# Altium schematic sheet Drive_Step_Motor.SchDoc(Drive_Step_Motor)
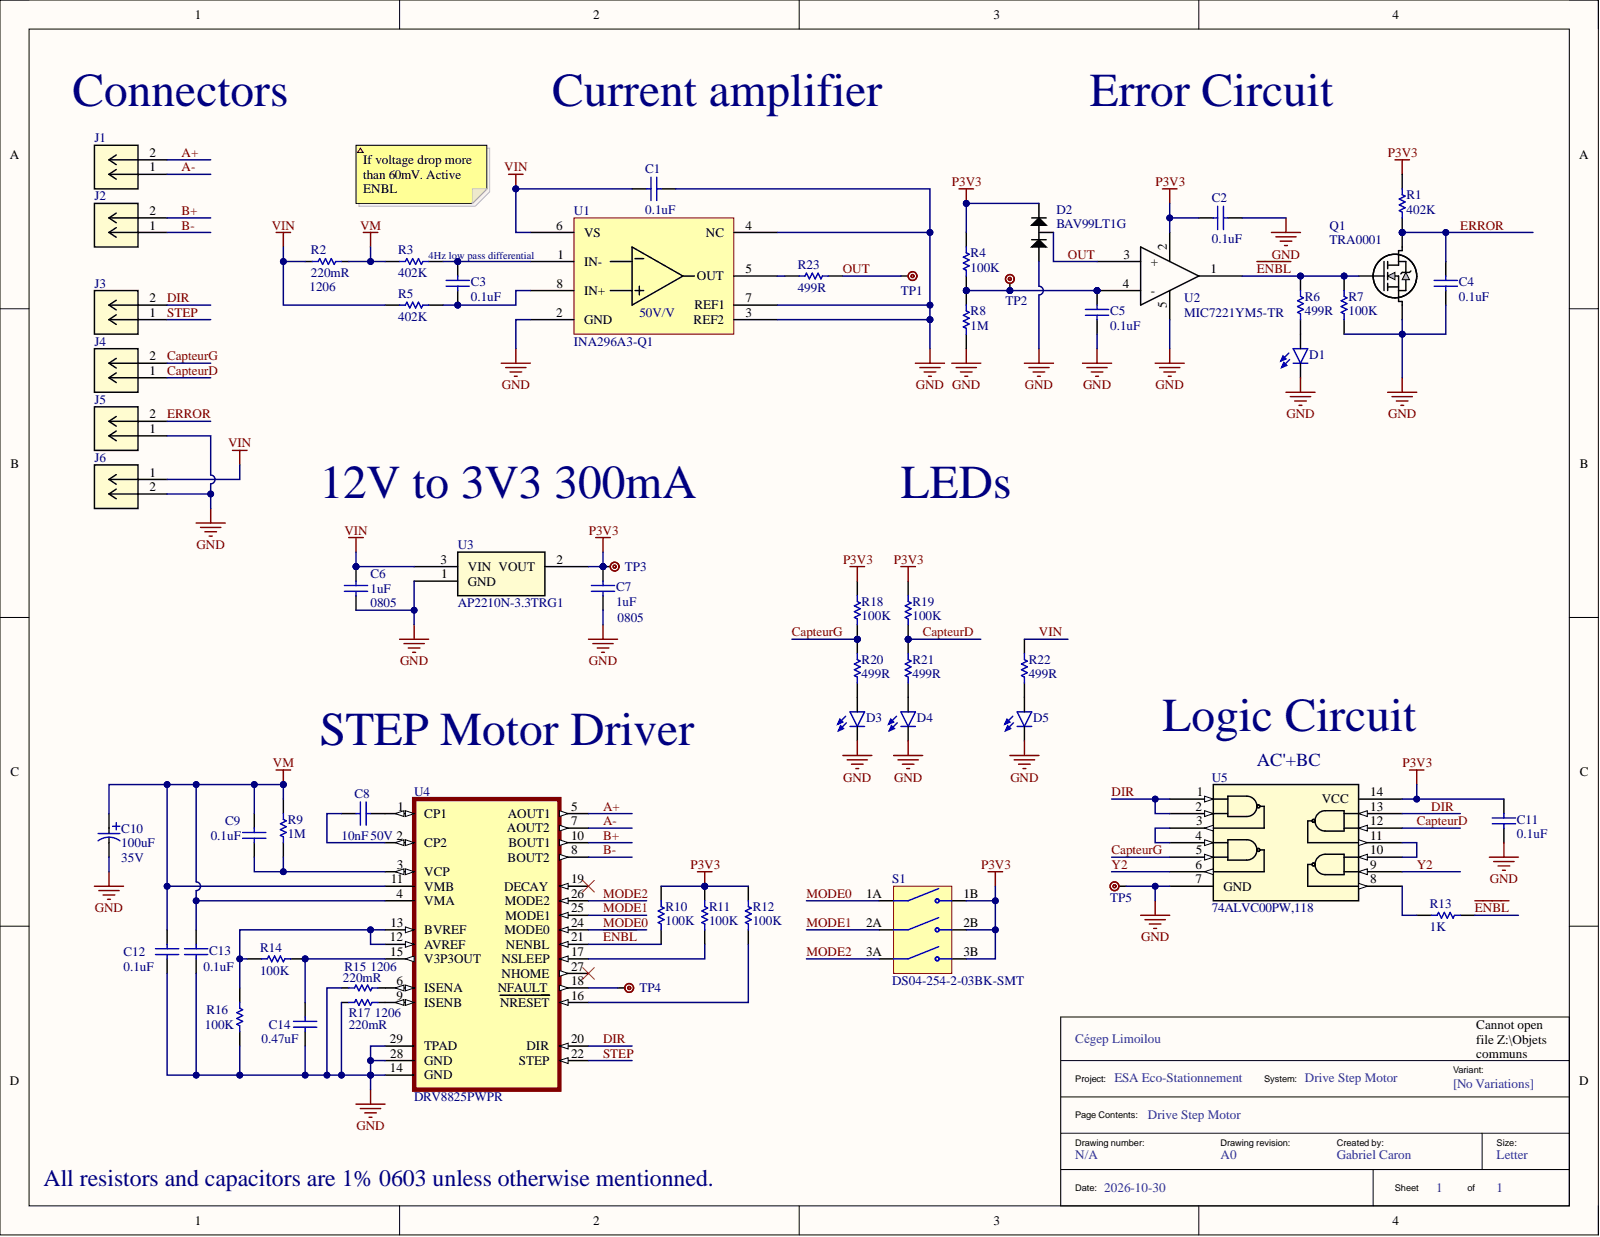
[diagram p0: Drive_Step_Motor.SchDoc]

## Parts
C1  value 0.1uF  [C0603-P]  (hidden comment: 06035C104KAT2A)
C2  value 0.1uF  [C0603-P]  (hidden comment: 06035C104KAT2A)
C3  value 0.1uF  [C0603-P]  (hidden comment: 06035C104KAT2A)
C4  value 0.1uF  [C0603-P]  (hidden comment: 06035C104KAT2A)
C5  value 0.1uF  [C0603-P]  (hidden comment: 06035C104KAT2A)
C6  value 1uF  Package: 0805  [C0805]  (hidden comment: CC0805KKX7R9BB105)
C7  value 1uF  Package: 0805  [C0805]  (hidden comment: CC0805KKX7R9BB105)
C8  value 10nF  [C0603]  (hidden comment: CC0603KRX7R9BB103)
C9  value 0.1uF  [C0603-P]  (hidden comment: 06035C104KAT2A)
C10  value 100uF  Voltage: 35V  [WCAP-ASLI_6.3X7.7(DXL)]  (hidden comment: 865080545012)
C11  value 0.1uF  [C0603-P]  (hidden comment: 06035C104KAT2A)
C12  value 0.1uF  [C0603-P]  (hidden comment: 06035C104KAT2A)
C13  value 0.1uF  [C0603-P]  (hidden comment: 06035C104KAT2A)
C14  value 0.47uF  [C0603]  (hidden comment: C1608X5R1H474K080AB)
D1  LTST-C190KRKT  [LEDC1608X35N]  (value not drawn)
D2  BAV99LT1G  [BAV99LT1G]
D3  LTST-C190KRKT  [LEDC1608X35N]  (value not drawn)
D4  LTST-C190KRKT  [LEDC1608X35N]  (value not drawn)
D5  MDIO0070  [LEDC1608X35N]  (value not drawn)
J1  VN02A1500000G  [CONN2_VN02A1500000G_AMP]  (value not drawn)
J2  VN02A1500000G  [CONN2_VN02A1500000G_AMP]  (value not drawn)
J3  VN02A1500000G  [CONN2_VN02A1500000G_AMP]  (value not drawn)
J4  VN02A1500000G  [CONN2_VN02A1500000G_AMP]  (value not drawn)
J5  VN02A1500000G  [CONN2_VN02A1500000G_AMP]  (value not drawn)
J6  VN02A1500000G  [CONN2_VN02A1500000G_AMP]  (value not drawn)
Q1  TRA0001  [TO-236AB_NEX]
R1  value 402K  [R0603]  (hidden comment: RC0603FR-07402KL)
R2  value 220mR  Package: 1206  [R1206]  (hidden comment: RL1206FR-070R22L)
R3  value 402K  [R0603]  (hidden comment: RC0603FR-07402KL)
R4  value 100K  [R0603]  (hidden comment: RC0603FR-07100KL)
R5  value 402K  [R0603]  (hidden comment: RC0603FR-07402KL)
R6  value 499R  [R0603]  (hidden comment: RT0603BRD07499RL)
R7  value 100K  [R0603]  (hidden comment: RC0603FR-07100KL)
R8  value 1M  [R0603]  (hidden comment: RC0603FR-071ML)
R9  value 1M  [R0603]  (hidden comment: RC0603FR-071ML)
R10  value 100K  [R0603]  (hidden comment: RC0603FR-07100KL)
R11  value 100K  [R0603]  (hidden comment: RC0603FR-07100KL)
R12  value 100K  [R0603]  (hidden comment: RC0603FR-07100KL)
R13  value 1K  [R0603]  (hidden comment: RC0603FR-071KL)
R14  value 100K  [R0603]  (hidden comment: RC0603FR-07100KL)
R15  value 220mR  [R1206]  (hidden comment: RL1206FR-070R22L)
R16  value 100K  [R0603]  (hidden comment: RC0603FR-07100KL)
R17  value 220mR  Package: 1206  [R1206]  (hidden comment: RL1206FR-070R22L)
R18  value 100K  [R0603]  (hidden comment: RC0603FR-07100KL)
R19  value 100K  [R0603]  (hidden comment: RC0603FR-07100KL)
R20  value 499R  [R0603]  (hidden comment: RT0603BRD07499RL)
R21  value 499R  [R0603]  (hidden comment: RT0603BRD07499RL)
R22  value 499R  [R0603]  (hidden comment: RT0603BRD07499RL)
R23  value 499R  [R0603]  (hidden comment: RT0603BRD07499RL)
S1  DS04-254-2-03BK-SMT  [SW_DS04-254-2-03BK-SMT]
TP1  5000  [TP_5000_MIN]  (value not drawn)
TP2  5000  [TP_5000_MIN]  (value not drawn)
TP3  5000  [TP_5000_MIN]  (value not drawn)
TP4  5000  [TP_5000_MIN]  (value not drawn)
TP5  5000  [TP_5000_MIN]  (value not drawn)
U1  INA296A3-Q1  [SOIC127P600X175-8N]
U2  MIC7221YM5-TR  [MIC7221YM5-TR]
U3  AP2210N-3.3TRG1  [SOT_3.3TRG1_DIO-L]
U4  DRV8825PWPR  [IC_TPS61196PWPRQ1]
U5  74ALVC00PW,118  [TSSOP14_SOT402-1_NEX]

## Nets
GND: C1.2 C2.2 C4.1 C5.1 C6.1 C7.1 C10.2 C11.1 C12.1 C13.1 C14.1 D1.C D2.1 D3.C D4.C D5.C J5.1 J6.2 Q1.2 R7.1 R8.1 R15.1 R16.2 R17.1 TP5.1 U1.2(GND) U1.3(REF2) U1.4(NC) U1.7(REF1) U2.5 U3.1 U4.14(GND) U4.28(GND) U4.29(TPAD) U5.7(GND)
P3V3: C2.1 C7.2 C11.2 D2.2 R1.2 R4.2 R10.2 R11.2 R12.2 R18.2 R19.2 S1.1B S1.2B S1.3B TP3.1 U2.2 U3.2 U5.14(VCC)
VM: C9.2 C10.1 C12.2 C13.2 R2.2 R3.1 R9.2 U4.4(VMA) U4.11(VMB)
A+: J1.2 U4.5(AOUT1)
A-: J1.1 U4.7(AOUT2)
B+: J2.2 U4.10(BOUT1)
B-: J2.1 U4.8(BOUT2)
CapteurD: J4.1 R19.1 R21.2 U5.12
CapteurG: J4.2 R18.1 R20.2 U5.5
DIR: J3.2 U4.20(DIR) U5.1 U5.2 U5.13
~{ENBL}: Q1.1 R6.2 R7.2 R10.1 R13.2 U2.1 U4.21(NENBL)
ERROR: C4.2 J5.2 Q1.3 R1.1
MODE0: S1.1A U4.24(MODE0)
MODE1: S1.2A U4.25(MODE1)
MODE2: S1.3A U4.26(MODE2)
OUT: D2.3 R23.2 TP1.1 U2.3(+)
STEP: J3.1 U4.22(STEP)
VIN: C1.1 C6.2 J6.1 R2.1 R5.1 R22.2 U1.6(VS) U3.3
Y2: U5.6 U5.9
NetC3_1: C3.1 R5.2 U1.8(IN+)
NetC3_2: C3.2 R3.2 U1.1(IN-)
NetC5_2: C5.2 R4.1 R8.2 TP2.1 U2.4(-)
NetC8_1: C8.1 U4.2(CP2)
NetC8_2: C8.2 U4.1(CP1)
NetC9_1: C9.1 R9.1 U4.3(VCP)
NetC14_2: C14.2 R14.2 U4.15(V3P3OUT)
NetD1_A: D1.A R6.1
NetD3_A: D3.A R20.1
NetD4_A: D4.A R21.1
NetD5_A: D5.A R22.1
NetR11_1: R11.1 U4.17(NSLEEP)
NetR12_1: R12.1 U4.16(NRESET)
NetR13_1: R13.1 U5.8
NetR14_1: R14.1 R16.1 U4.12(AVREF) U4.13(BVREF)
NetR15_2: R15.2 U4.6(ISENA)
NetR17_2: R17.2 U4.9(ISENB)
NetR23_1: R23.1 U1.5(OUT)
NetTP4_1: TP4.1 U4.18(NFAULT)
NetU5_3: U5.3 U5.4
NetU5_10: U5.10 U5.11

Not connected: U4 19(DECAY) 27(NHOME)

## Library data of the parts
C1-C5 C9 C11-C13 = CAP0021: CAP CER 0.1UF 50V X7R 0603 10%; Manufacturer: KYOCERA AVX; ID_CEP: MCAP0021; Verif_1: RCA le 2022-02-21; Component Kind: Standard; Dielectric: X7R; Fitted: Fitted; Package: 0603; PN_Manuf: 06035C104KAT2A; Library Name: Eco-Stationnement.SchLib
C6 C7 = CAP0002: CAP CER 1UF 50V X7R 0805; Manufacturer: Yageo; ID_CEP: MCAP0002; Verif_1: JSMG; Component Kind: Standard; Cost: 0.08; Fitted: Fitted; LatestRevisionDate: 17-Jul-2002; LatestRevisionNote: Re-released for DXP Platform.; PackageDescription: Radial Cap, Thru-Hole; 2 Leads; 0.3 in Pin Spacing; PN_Manuf: CC0805KKX7R9BB105; Published: 8-Jun-2000; Library Name: Eco-Stationnement.SchLib; Publisher: Altium Limited
C8 = CAP0100: CAP CER 10nF 50V X7R 0603; Manufacturer: Yageo; ID_CEP: MCAP0100; Verif_1: FT-2025/02/27; Verif_2: RCA le 2025-03-05; Component Kind: Standard; Fitted: Fitted; Package: 0603; PN_Manuf: CC0603KRX7R9BB103; Voltage: 50V; Library Name: Eco-Stationnement.SchLib
C10 = 865080545012: CAP ALUM 100UF 20% 35V SMD; Manufacturer: Würth Elektronik; Component Kind: Standard; Fitted: Fitted; Package: 0603; PN_Manuf: 865080545012; Library Name: Eco-Stationnement.SchLib
C14 = CAP0124: CAP CER 0.47UF 50V X5R 0603; Manufacturer: Yageo; ID_CEP: MCAP0124; Verif_1: FT-2025/02/27; Verif_2: RCA le 2025-03-05; Component Kind: Standard; Fitted: Fitted; Package: 0603; PN_Manuf: C1608X5R1H474K080AB; Voltage: 50V; Library Name: Eco-Stationnement.SchLib
D1 D3 D4 = LTST-C190KRKT: LED RED CLEAR CHIP SMD; Manufacturer: Lite-On Inc.; Availability: In Stock; Check_prices: https://www.snapeda.com/parts/LTST-C190KRKT/Lite-On+Inc./view-part/?ref=eda; Component Kind: Standard; ComponentLink1Description: New link; Fitted: Fitted; MF: Lite-On Inc.; MP: LTST-C190KRKT; Package: 0603 Lite-On; PN_Manuf: LTST-C190KRKT; Price: None; Purchase-URL: https://pricing.snapeda.com/search/part/LTST-C190KRKT/?ref=eda; SnapEDA_Link: https://www.snapeda.com/parts/LTST-C190KRKT/Lite-On+Inc./view-part/?ref=snap; Library Name: Eco-Stationnement.SchLib
D2 = DIO0002: Diode Array 1 Pair Series Connection Standard 100V 215mA (DC) SOT-23-3; Manufacturer: ON Semiconductor; ID_CEP: MDIO0002; Verif_1: JSMG; Component Kind: Standard; Cost: 0.18; Fitted: Fitted; Package: 3-SOT-23; PN_Manuf: BAV99LT1G; Library Name: Eco-Stationnement.SchLib
D5 = MDIO0070: LED GREEN CLEAR SMD; Manufacturer: Lite-On Inc.; MP: LTST-C191KGKT; Availability: In Stock; Check_prices: https://www.snapeda.com/parts/LTST-C190KRKT/Lite-On+Inc./view-part/?ref=eda; Component Kind: Standard; ComponentLink1Description: New link; Fitted: Fitted; ID_CEP: DIO0070; MF: Lite-On Inc.; Package: 0603 Lite-On; PN_Manuf: LTST-C191KGKT; Price: None; Purchase-URL: https://pricing.snapeda.com/search/part/LTST-C190KRKT/?ref=eda; SnapEDA_Link: https://www.snapeda.com/parts/LTST-C190KRKT/Lite-On+Inc./view-part/?ref=snap; Library Name: Eco-Stationnement.SchLib
J1-J6 = VN02A1500000G: TERM BLK 2P SIDE ENT 2.54MM PCB; Manufacturer: Amphenol ICC; Component Kind: Standard; Fitted: Fitted; Manufacturer_Name: Amphenol ICC; Manufacturer_Part_Number: VN02A1500000G; PN_Manuf: VN02A1500000G; RefDes: RefDes; Type: Type; Copyright: Copyright (C) 2025 Ultra Librarian. All rights reserved.; Library Name: Eco-Stationnement.SchLib
Q1 = TRA0001: MOSFET N-CH 60V 300MA TO236AB; Manufacturer: Nexperia; Manufacturer_Part_Number: 2N7002,215; Verif_1: GC; Component Kind: Standard; Fitted: Fitted; ID_CEP: TRA0001; Manufacturer_Name: Nexperia; PN_Manuf: 2N7002,215; Copyright: Copyright (C) 2025 Ultra Librarian. All rights reserved.; Library Name: Eco-Stationnement.SchLib
R1 R3 R5 = RC0603FR-07402KL: RES 402K OHM 1% 1/10W 0603; Manufacturer: YAGEO; Verif_1: JSMG; Component Kind: Standard; Cost: 0.01; Fitted: Fitted; LatestRevisionDate: 17-Jul-2002; LatestRevisionNote: Re-released for DXP Platform.; Package: 0603; PackageDescription: Axial Device, Thru-Hole; 2 Leads; 0.3 in Pin Spacing; PackageReference: AXIAL-0.3; PN_Manuf: RC0603FR-07402KL; Published: 8-Jun-2000; Library Name: Eco-Stationnement.SchLib; Publisher: Altium Limited
R2 R15 R17 = RL1206FR-070R22L: RES 0.22 OHM 1% 1/4W 1206; Manufacturer: YAGEO; Verif_1: GC; Component Kind: Standard; Cost: 0.01; Fitted: Fitted; LatestRevisionDate: 17-Jul-2002; LatestRevisionNote: Re-released for DXP Platform.; PackageDescription: Axial Device, Thru-Hole; 2 Leads; 0.3 in Pin Spacing; PackageReference: AXIAL-0.3; PN_Manuf: RL1206FR-070R22L; Published: 8-Jun-2000; Library Name: Eco-Stationnement.SchLib; Publisher: Altium Limited
  R15: Package: 1206
R4 R7 R10-R12 R14 R16 R18 R19 = RES0003: RES SMD 100K OHM 1% 1/10W 0603; Manufacturer: YAGEO; ID_CEP: MRES0003; Verif_1: JSMG; Component Kind: Standard; Cost: 0.01; Fitted: Fitted; LatestRevisionDate: 17-Jul-2002; LatestRevisionNote: Re-released for DXP Platform.; Package: 0603; PackageDescription: Axial Device, Thru-Hole; 2 Leads; 0.3 in Pin Spacing; PackageReference: AXIAL-0.3; PN_Manuf: RC0603FR-07100KL; Published: 8-Jun-2000; Library Name: Eco-Stationnement.SchLib; Publisher: Altium Limited
R6 R20-R23 = RES0015: RES SMD 499 OHM 0.1% 1/10W 0603; Manufacturer: YAGEO; ID_CEP: MRES0015; Verif_1: JSMG; Component Kind: Standard; Cost: X; Fitted: Fitted; LatestRevisionDate: 17-Jul-2002; LatestRevisionNote: Re-released for DXP Platform.; Package: 0603; PackageDescription: Axial Device, Thru-Hole; 2 Leads; 0.3 in Pin Spacing; PackageReference: AXIAL-0.3; PN_Manuf: RT0603BRD07499RL; Published: 8-Jun-2000; Library Name: Eco-Stationnement.SchLib; Publisher: Altium Limited
R8 R9 = RES0023: RES SMD 1M OHM 1% 1/10W 0603; Manufacturer: Yageo; ID_CEP: MRES0023; Verif_1: JSMG; Component Kind: Standard; Cost: 0.01; Fitted: Fitted; LatestRevisionDate: 17-Jul-2002; LatestRevisionNote: Re-released for DXP Platform.; Package: 0603; PackageDescription: Axial Device, Thru-Hole; 2 Leads; 0.3 in Pin Spacing; PackageReference: AXIAL-0.3; PN_Manuf: RC0603FR-071ML; Published: 8-Jun-2000; Library Name: Eco-Stationnement.SchLib; Publisher: Altium Limited
R13 = RES0001: RES SMD 1K OHM 1% 1/10W 0603; Manufacturer: Yageo; ID_CEP: MRES0001; Verif_1: JSMG; Component Kind: Standard; Cost: 0.01; Fitted: Fitted; LatestRevisionDate: 17-Jul-2002; LatestRevisionNote: Re-released for DXP Platform.; Package: 0603; PackageDescription: Axial Device, Thru-Hole; 2 Leads; 0.3 in Pin Spacing; PackageReference: AXIAL-0.3; PN_Manuf: RC0603FR-071KL; Published: 8-Jun-2000; Library Name: Eco-Stationnement.SchLib; Publisher: Altium Limited
S1 = DS04-254-2-03BK-SMT: 3 Position, Surface Mount, 2.54 mm Pitch, Flat Slide Actuator, Flat Bottom, SMT Pin, DIP Switch; Manufacturer: Same Sky; Check_prices: https://www.snapeda.com/parts/DS04-254-2-03BK-SMT/Same+Sky/view-part/?ref=eda; Component Kind: Standard; Fitted: Fitted; MF: Same Sky; MP: DS04-254-2-03BK-SMT; Package: None; PN_Manuf: DS04-254-2-03BK-SMT; SnapEDA_Link: https://www.snapeda.com/parts/DS04-254-2-03BK-SMT/Same+Sky/view-part/?ref=snap; Library Name: Eco-Stationnement.SchLib
TP1-TP5 = DIV0034: PC TEST POINT MINIATURE RED 1mm; Manufacturer: Keystone Electronics; Fitted: Not Fitted; ID_CEP: MDIV0034; Verif_1: RCA le 2024-03-27; Component Kind: Standard; PN_Manuf: 5000; Library Name: Eco-Stationnement.SchLib
U1 = INA296A3-Q1: Current Sense Amplifier 1 Circuit Single-Ended 8-SOIC; Manufacturer: Texas Instruments; Component Kind: Standard; Fitted: Fitted; PN_Manuf: INA296A3-Q1; Library Name: Eco-Stationnement.SchLib
U2 = MIC7221YM5-TR: IC COMPARATOR 1 GEN PUR SOT23-5; Manufacturer: Microchip; Component Kind: Standard; Fitted: Fitted; PN_Manuf: MIC7221YM5-TR; Library Name: Eco-Stationnement.SchLib
U3 = AP2210N-3.3TRG1: IC REG LINEAR 3.3V 300MA SOT23-3; Manufacturer: Diodes Inc; Component Kind: Standard; Fitted: Fitted; Manufacturer_Name: Diodes Inc; Manufacturer_Part_Number: AP2210N-3.3TRG1; PN_Manuf: AP2210N-3.3TRG1; RefDes: RefDes; Type: Type; Copyright: Copyright (C) 2025 Ultra Librarian. All rights reserved.; Library Name: Eco-Stationnement.SchLib
U4 = DRV8825PWPR: 45-V, 2.5-A bipolar stepper motor driver with current regulation and 1/32 microstepping Â; Manufacturer: Texas Instruments; Check_prices: https://www.snapeda.com/parts/DRV8825PWPR/Texas+Instruments/view-part/?ref=eda; Component Kind: Standard; Fitted: Fitted; MF: Texas Instruments; MP: DRV8825PWPR; Package: HTSSOP-28 Texas Instruments; PN_Manuf: DRV8825PWPR; SnapEDA_Link: https://www.snapeda.com/parts/DRV8825PWPR/Texas+Instruments/view-part/?ref=snap; Library Name: Eco-Stationnement.SchLib
U5 = 74ALVC00PW,118: NAND Gate IC 4 Channel 14-TSSOP; ID_CEP: Nexperia; Component Kind: Standard; Fitted: Fitted; Manufacturer: Manufacturer; Manufacturer_Name: Nexperia; Manufacturer_Part_Number: 74ALVC00PW,118; PN_Manuf: 74ALVC00PW,118; RefDes: RefDes; Type: Type; Copyright: Copyright (C) 2025 Ultra Librarian. All rights reserved.; Library Name: Eco-Stationnement.SchLib
